# Altium schematic sheet Core_V2.SchDoc
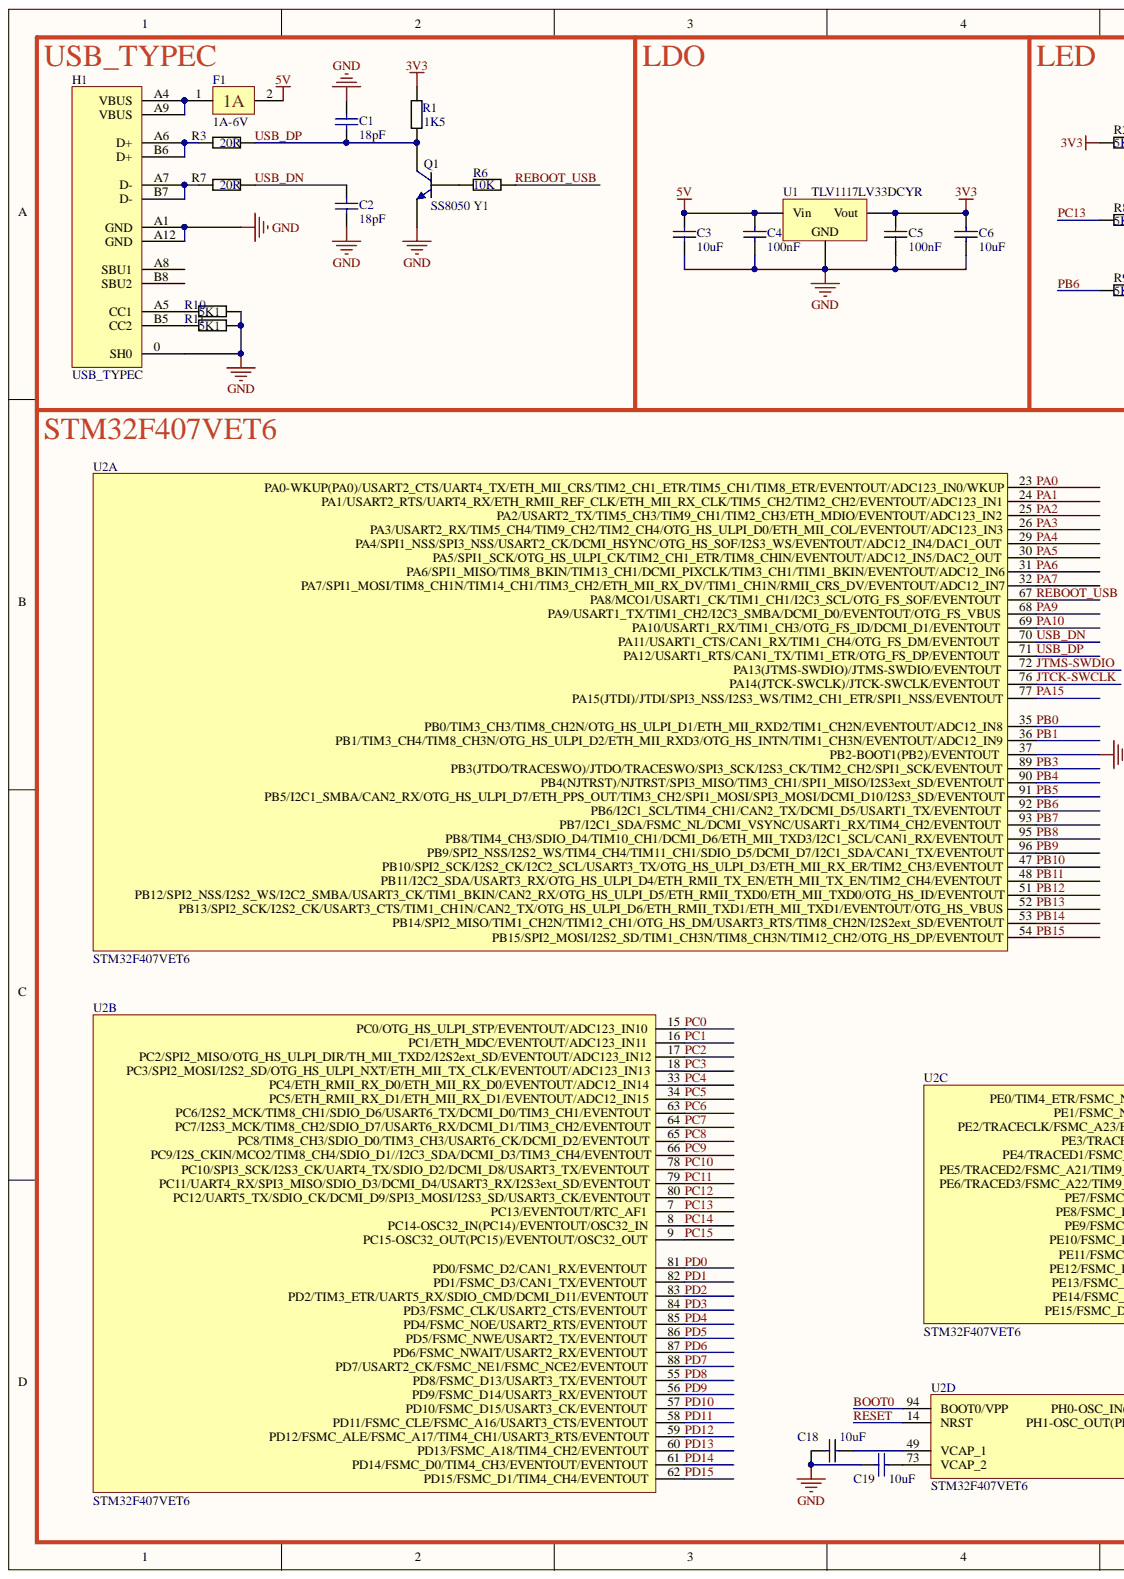
[diagram p0: Core_V2.SchDoc, left]
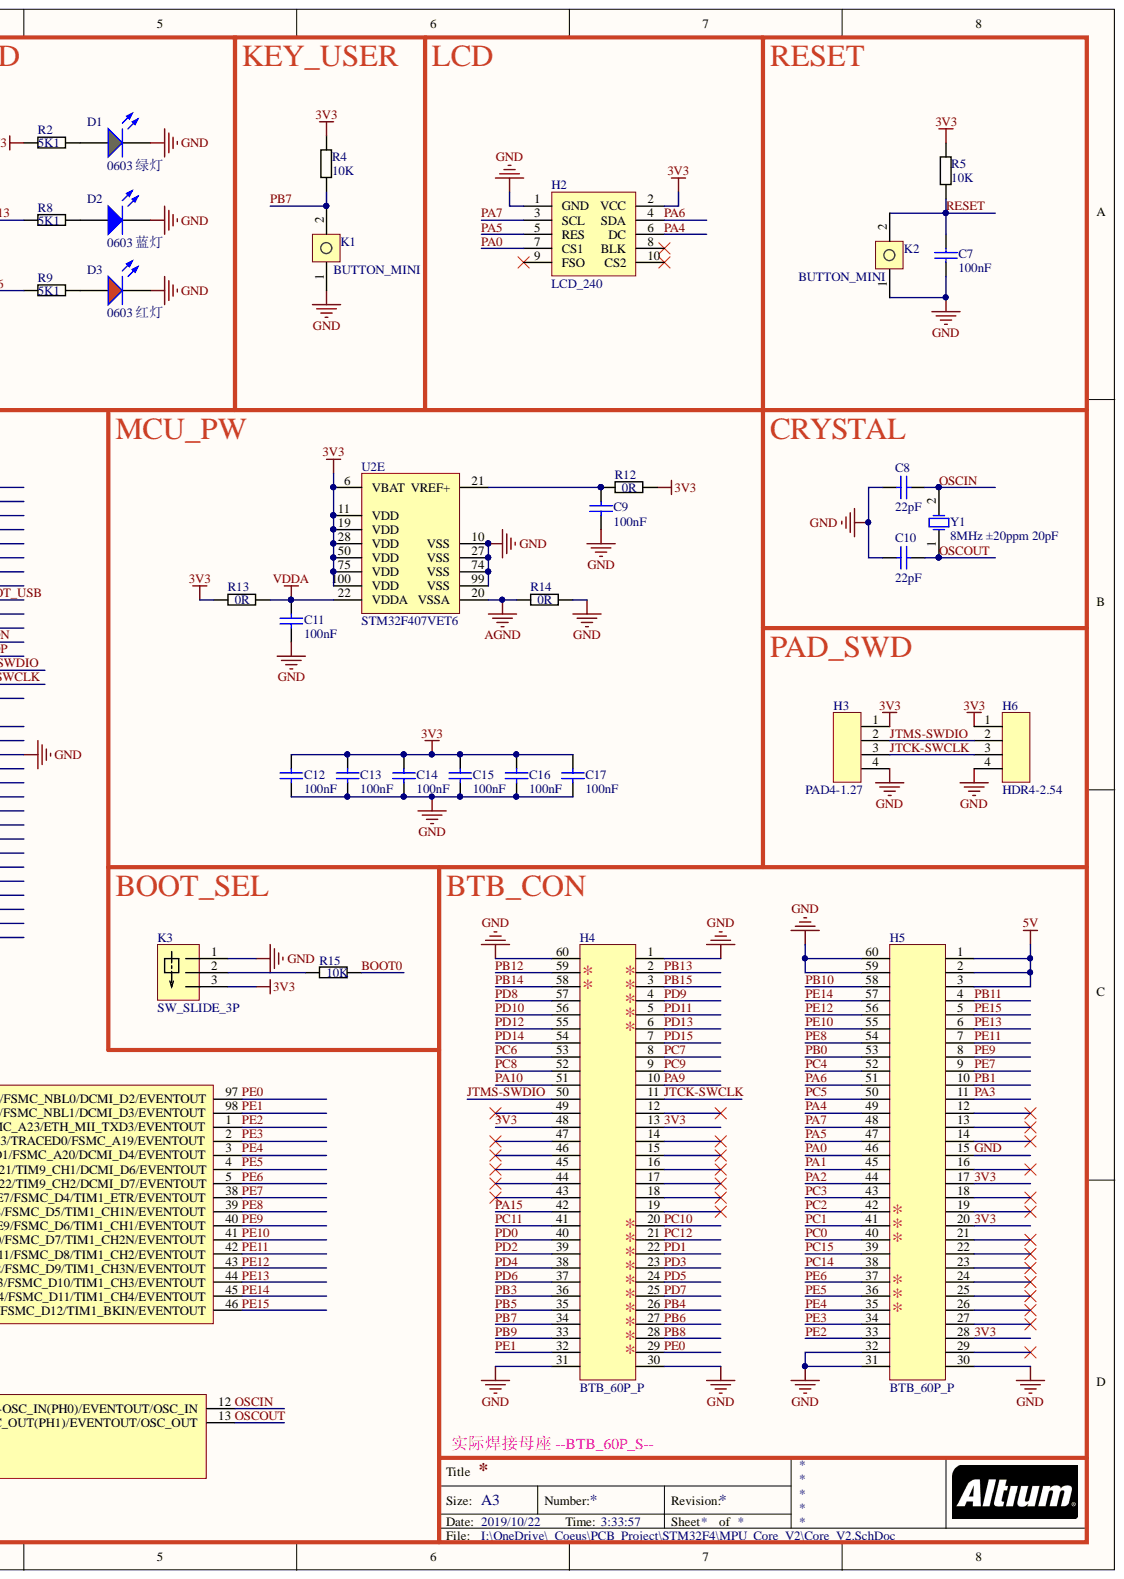
[diagram p1: Core_V2.SchDoc, right]

## Parts
C1  18pF  [0603_C]
C2  18pF  [0603_C]
C3  10uF  [0603_C]
C4  100nF  [0603_C]
C5  100nF  [0603_C]
C6  10uF  [0603_C]
C7  100nF  [0603_C]
C8  22pF  [0603_C]
C9  100nF  [0603_C]
C10  22pF  [0603_C]
C11  100nF  [0603_C]
C12  100nF  [0603_C]
C13  100nF  [0603_C]
C14  100nF  [0603_C]
C15  100nF  [0603_C]
C16  100nF  [0603_C]
C17  100nF  [0603_C]
C18  10uF  [0603_C]
C19  10uF  [0603_C]
D1  0603 绿灯  Package: 0603  [0603_LED_GREEN]
D2  0603 蓝灯  Package: 0603  [0603_LED_BLUE]
D3  0603 红灯  Package: 0603  [0603_LED]
F1  1A-6V  [0805_FUSE]
H1  USB_TYPEC  [USB_TYPEC]
H2  LCD_240  [LCD_240]
H3  PAD4-1.27  [HDR4-1.27]
H4  BTB_60P_P  [BTB_60P_P]
H5  BTB_60P_P  [BTB_60P_P]
H6  HDR4-2.54  [HDR4-2.54]
K1  BUTTON_MINI  [BUTTON_MINI]
K2  BUTTON_MINI  [BUTTON_MINI]
K3  SW_SLIDE_3P  [SW_SLIDE_3P]
Q1  SS8050 Y1  [SOT-23(SOT-23-3)]
R1  1K5  [0603_R]
R2  5K1  [0603_R]
R3  20R  [0603_R]
R4  10K  [0603_R]
R5  10K  [0603_R]
R6  10K  [0603_R]
R7  20R  [0603_R]
R8  5K1  [0603_R]
R9  5K1  [0603_R]
R10  5K1  [0603_R]
R11  5K1  [0603_R]
R12  0R  [0603_R]
R13  0R  [0603_R]
R14  0R  [0603_R]
R15  10K  [0603_R]
U1  TLV1117LV33DCYR  [SOT-223]
U2  STM32F407VET6  [LQFP-100_14x14x05P]  (parts A,B,C,D,E)
Y1  8MHz ±20ppm 20pF  [SMD-5032_2P]

## Nets
5V: C3.2 C4.2 F1.2 H5.1 H5.2 H5.3 U1.3
AGND: R14.1 U2.20(VSSA)
VDDA: C11.2 R13.2 U2.22(VDDA)
3V3: C5.2 C6.2 C12.2 C13.2 C14.2 C15.2 C16.2 C17.2 H2.2(VCC) H3.1 H4.13 H4.48 H5.17 H5.20 H5.28 H6.1 K3.3 R1.2 R2.1 R4.2 R5.2 R12.2 R13.1 U1.2 U2.6(VBAT) U2.11(VDD) U2.19(VDD) U2.28(VDD) U2.50(VDD) U2.75(VDD) U2.100(VDD)
BOOT0: R15.2 U2.94(BOOT0/VPP)
GND: C1.2 C2.1 C3.1 C4.1 C5.1 C6.1 C7.1 C8.1 C9.1 C10.1 C11.1 C12.1 C13.1 C14.1 C15.1 C16.1 C17.1 C18.1 C19.1 D1.K D2.K D3.K H1.0(SH0) H1.A1(GND) H1.A12(GND) H2.1(GND) H3.4 H4.1 H4.30 H4.31 H4.60 H5.15 H5.30 H5.31 H5.32 H5.59 H5.60 H6.4 K1.1 K2.1 K3.1 Q1.2 R10.2 R11.2 R14.2 U1.1 U2.10(VSS) U2.27(VSS) U2.37(PB2-BOOT1(PB2)/EVENTOUT) U2.74(VSS) U2.99(VSS)
JTCK-SWCLK: H3.3 H4.11 H6.3 U2.76(PA14(JTCK-SWCLK)/JTCK-SWCLK/EVENTOUT)
JTMS-SWDIO: H3.2 H4.50 H6.2 U2.72(PA13(JTMS-SWDIO)/JTMS-SWDIO/EVENTOUT)
OSCIN: C8.2 U2.12(PH0-OSC_IN(PH0)/EVENTOUT/OSC_IN) Y1.2
OSCOUT: C10.2 U2.13(PH1-OSC_OUT(PH1)/EVENTOUT/OSC_OUT) Y1.1
PA0: H2.7(CS1) H5.46 U2.23(PA0-WKUP(PA0)/USART2_CTS/UART4_TX/ETH_MII_CRS/TIM2_CH1_ETR/TIM5_CH1/TIM8_ETR/EVENTOUT/ADC123_IN0/WKUP)
PA1: H5.45 U2.24(PA1/USART2_RTS/UART4_RX/ETH_RMII_REF_CLK/ETH_MII_RX_CLK/TIM5_CH2/TIM2_CH2/EVENTOUT/ADC123_IN1)
PA2: H5.44 U2.25(PA2/USART2_TX/TIM5_CH3/TIM9_CH1/TIM2_CH3/ETH_MDIO/EVENTOUT/ADC123_IN2)
PA3: H5.11 U2.26(PA3/USART2_RX/TIM5_CH4/TIM9_CH2/TIM2_CH4/OTG_HS_ULPI_D0/ETH_MII_COL/EVENTOUT/ADC123_IN3)
PA4: H2.6(DC) H5.49 U2.29(PA4/SPI1_NSS/SPI3_NSS/USART2_CK/DCMI_HSYNC/OTG_HS_SOF/I2S3_WS/EVENTOUT/ADC12_IN4/DAC1_OUT)
PA5: H2.5(RES) H5.47 U2.30(PA5/SPI1_SCK/OTG_HS_ULPI_CK/TIM2_CH1_ETR/TIM8_CHIN/EVENTOUT/ADC12_IN5/DAC2_OUT)
PA6: H2.4(SDA) H5.51 U2.31(PA6/SPI1_MISO/TIM8_BKIN/TIM13_CH1/DCMI_PIXCLK/TIM3_CH1/TIM1_BKIN/EVENTOUT/ADC12_IN6)
PA7: H2.3(SCL) H5.48 U2.32(PA7/SPI1_MOSI/TIM8_CH1N/TIM14_CH1/TIM3_CH2/ETH_MII_RX_DV/TIM1_CH1N/RMII_CRS_DV/EVENTOUT/ADC12_IN7)
PA9: H4.10 U2.68(PA9/USART1_TX/TIM1_CH2/I2C3_SMBA/DCMI_D0/EVENTOUT/OTG_FS_VBUS)
PA10: H4.51 U2.69(PA10/USART1_RX/TIM1_CH3/OTG_FS_ID/DCMI_D1/EVENTOUT)
PA15: H4.42 U2.77(PA15(JTDI)/JTDI/SPI3_NSS/I2S3_WS/TIM2_CH1_ETR/SPI1_NSS/EVENTOUT)
PB0: H5.53 U2.35(PB0/TIM3_CH3/TIM8_CH2N/OTG_HS_ULPI_D1/ETH_MII_RXD2/TIM1_CH2N/EVENTOUT/ADC12_IN8)
PB1: H5.10 U2.36(PB1/TIM3_CH4/TIM8_CH3N/OTG_HS_ULPI_D2/ETH_MII_RXD3/OTG_HS_INTN/TIM1_CH3N/EVENTOUT/ADC12_IN9)
PB3: H4.36 U2.89(PB3(JTDO/TRACESWO)/JTDO/TRACESWO/SPI3_SCK/I2S3_CK/TIM2_CH2/SPI1_SCK/EVENTOUT)
PB4: H4.26(*) U2.90(PB4(NJTRST)/NJTRST/SPI3_MISO/TIM3_CH1/SPI1_MISO/I2S3ext_SD/EVENTOUT)
PB5: H4.35 U2.91(PB5/I2C1_SMBA/CAN2_RX/OTG_HS_ULPI_D7/ETH_PPS_OUT/TIM3_CH2/SPI1_MOSI/SPI3_MOSI/DCMI_D10/I2S3_SD/EVENTOUT)
PB6: H4.27(*) R9.1 U2.92(PB6/I2C1_SCL/TIM4_CH1/CAN2_TX/DCMI_D5/USART1_TX/EVENTOUT)
PB7: H4.34 K1.2 R4.1 U2.93(PB7/I2C1_SDA/FSMC_NL/DCMI_VSYNC/USART1_RX/TIM4_CH2/EVENTOUT)
PB8: H4.28(*) U2.95(PB8/TIM4_CH3/SDIO_D4/TIM10_CH1/DCMI_D6/ETH_MII_TXD3/I2C1_SCL/CAN1_RX/EVENTOUT)
PB9: H4.33 U2.96(PB9/SPI2_NSS/I2S2_WS/TIM4_CH4/TIM11_CH1/SDIO_D5/DCMI_D7/I2C1_SDA/CAN1_TX/EVENTOUT)
PB10: H5.58 U2.47(PB10/SPI2_SCK/I2S2_CK/I2C2_SCL/USART3_TX/OTG_HS_ULPI_D3/ETH_MII_RX_ER/TIM2_CH3/EVENTOUT)
PB11: H5.4 U2.48(PB11/I2C2_SDA/USART3_RX/OTG_HS_ULPI_D4/ETH_RMII_TX_EN/ETH_MII_TX_EN/TIM2_CH4/EVENTOUT)
PB12: H4.59(*) U2.51(PB12/SPI2_NSS/I2S2_WS/I2C2_SMBA/USART3_CK/TIM1_BKIN/CAN2_RX/OTG_HS_ULPI_D5/ETH_RMII_TXD0/ETH_MII_TXD0/OTG_HS_ID/EVENTOUT)
PB13: H4.2(*) U2.52(PB13/SPI2_SCK/I2S2_CK/USART3_CTS/TIM1_CH1N/CAN2_TX/OTG_HS_ULPI_D6/ETH_RMII_TXD1/ETH_MII_TXD1/EVENTOUT/OTG_HS_VBUS)
PB14: H4.58(*) U2.53(PB14/SPI2_MISO/TIM1_CH2N/TIM12_CH1/OTG_HS_DM/USART3_RTS/TIM8_CH2N/I2S2ext_SD/EVENTOUT)
PB15: H4.3(*) U2.54(PB15/SPI2_MOSI/I2S2_SD/TIM1_CH3N/TIM8_CH3N/TIM12_CH2/OTG_HS_DP/EVENTOUT)
PC0: H5.40(*) U2.15(PC0/OTG_HS_ULPI_STP/EVENTOUT/ADC123_IN10)
PC1: H5.41(*) U2.16(PC1/ETH_MDC/EVENTOUT/ADC123_IN11)
PC2: H5.42(*) U2.17(PC2/SPI2_MISO/OTG_HS_ULPI_DIR/TH_MII_TXD2/I2S2ext_SD/EVENTOUT/ADC123_IN12)
PC3: H5.43 U2.18(PC3/SPI2_MOSI/I2S2_SD/OTG_HS_ULPI_NXT/ETH_MII_TX_CLK/EVENTOUT/ADC123_IN13)
PC4: H5.52 U2.33(PC4/ETH_RMII_RX_D0/ETH_MII_RX_D0/EVENTOUT/ADC12_IN14)
PC5: H5.50 U2.34(PC5/ETH_RMII_RX_D1/ETH_MII_RX_D1/EVENTOUT/ADC12_IN15)
PC6: H4.53 U2.63(PC6/I2S2_MCK/TIM8_CH1/SDIO_D6/USART6_TX/DCMI_D0/TIM3_CH1/EVENTOUT)
PC7: H4.8 U2.64(PC7/I2S3_MCK/TIM8_CH2/SDIO_D7/USART6_RX/DCMI_D1/TIM3_CH2/EVENTOUT)
PC8: H4.52 U2.65(PC8/TIM8_CH3/SDIO_D0/TIM3_CH3/USART6_CK/DCMI_D2/EVENTOUT)
PC9: H4.9 U2.66(PC9/I2S_CKIN/MCO2/TIM8_CH4/SDIO_D1//I2C3_SDA/DCMI_D3/TIM3_CH4/EVENTOUT)
PC10: H4.20(*) U2.78(PC10/SPI3_SCK/I2S3_CK/UART4_TX/SDIO_D2/DCMI_D8/USART3_TX/EVENTOUT)
PC11: H4.41 U2.79(PC11/UART4_RX/SPI3_MISO/SDIO_D3/DCMI_D4/USART3_RX/I2S3ext_SD/EVENTOUT)
PC12: H4.21(*) U2.80(PC12/UART5_TX/SDIO_CK/DCMI_D9/SPI3_MOSI/I2S3_SD/USART3_CK/EVENTOUT)
PC13: R8.1 U2.7(PC13/EVENTOUT/RTC_AF1)
PC14: H5.38 U2.8(PC14-OSC32_IN(PC14)/EVENTOUT/OSC32_IN)
PC15: H5.39 U2.9(PC15-OSC32_OUT(PC15)/EVENTOUT/OSC32_OUT)
PD0: H4.40 U2.81(PD0/FSMC_D2/CAN1_RX/EVENTOUT)
PD1: H4.22(*) U2.82(PD1/FSMC_D3/CAN1_TX/EVENTOUT)
PD2: H4.39 U2.83(PD2/TIM3_ETR/UART5_RX/SDIO_CMD/DCMI_D11/EVENTOUT)
PD3: H4.23(*) U2.84(PD3/FSMC_CLK/USART2_CTS/EVENTOUT)
PD4: H4.38 U2.85(PD4/FSMC_NOE/USART2_RTS/EVENTOUT)
PD5: H4.24(*) U2.86(PD5/FSMC_NWE/USART2_TX/EVENTOUT)
PD6: H4.37 U2.87(PD6/FSMC_NWAIT/USART2_RX/EVENTOUT)
PD7: H4.25(*) U2.88(PD7/USART2_CK/FSMC_NE1/FSMC_NCE2/EVENTOUT)
PD8: H4.57 U2.55(PD8/FSMC_D13/USART3_TX/EVENTOUT)
PD9: H4.4(*) U2.56(PD9/FSMC_D14/USART3_RX/EVENTOUT)
PD10: H4.56 U2.57(PD10/FSMC_D15/USART3_CK/EVENTOUT)
PD11: H4.5(*) U2.58(PD11/FSMC_CLE/FSMC_A16/USART3_CTS/EVENTOUT)
PD12: H4.55 U2.59(PD12/FSMC_ALE/FSMC_A17/TIM4_CH1/USART3_RTS/EVENTOUT)
PD13: H4.6(*) U2.60(PD13/FSMC_A18/TIM4_CH2/EVENTOUT)
PD14: H4.54 U2.61(PD14/FSMC_D0/TIM4_CH3/EVENTOUT/EVENTOUT)
PD15: H4.7 U2.62(PD15/FSMC_D1/TIM4_CH4/EVENTOUT)
PE0: H4.29(*) U2.97(PE0/TIM4_ETR/FSMC_NBL0/DCMI_D2/EVENTOUT)
PE1: H4.32 U2.98(PE1/FSMC_NBL1/DCMI_D3/EVENTOUT)
PE2: H5.33 U2.1(PE2/TRACECLK/FSMC_A23/ETH_MII_TXD3/EVENTOUT)
PE3: H5.34 U2.2(PE3/TRACED0/FSMC_A19/EVENTOUT)
PE4: H5.35(*) U2.3(PE4/TRACED1/FSMC_A20/DCMI_D4/EVENTOUT)
PE5: H5.36(*) U2.4(PE5/TRACED2/FSMC_A21/TIM9_CH1/DCMI_D6/EVENTOUT)
PE6: H5.37(*) U2.5(PE6/TRACED3/FSMC_A22/TIM9_CH2/DCMI_D7/EVENTOUT)
PE7: H5.9 U2.38(PE7/FSMC_D4/TIM1_ETR/EVENTOUT)
PE8: H5.54 U2.39(PE8/FSMC_D5/TIM1_CH1N/EVENTOUT)
PE9: H5.8 U2.40(PE9/FSMC_D6/TIM1_CH1/EVENTOUT)
PE10: H5.55 U2.41(PE10/FSMC_D7/TIM1_CH2N/EVENTOUT)
PE11: H5.7 U2.42(PE11/FSMC_D8/TIM1_CH2/EVENTOUT)
PE12: H5.56 U2.43(PE12/FSMC_D9/TIM1_CH3N/EVENTOUT)
PE13: H5.6 U2.44(PE13/FSMC_D10/TIM1_CH3/EVENTOUT)
PE14: H5.57 U2.45(PE14/FSMC_D11/TIM1_CH4/EVENTOUT)
PE15: H5.5 U2.46(PE15/FSMC_D12/TIM1_BKIN/EVENTOUT)
REBOOT_USB: R6.1 U2.67(PA8/MCO1/USART1_CK/TIM1_CH1/I2C3_SCL/OTG_FS_SOF/EVENTOUT)
RESET: C7.2 K2.2 R5.1 U2.14(NRST)
USB_DN: C2.2 R7.2 U2.70(PA11/USART1_CTS/CAN1_RX/TIM1_CH4/OTG_FS_DM/EVENTOUT)
USB_DP: C1.1 Q1.3 R1.1 R3.2 U2.71(PA12/USART1_RTS/CAN1_TX/TIM1_ETR/OTG_FS_DP/EVENTOUT)
NetC9_2: C9.2 R12.1 U2.21(VREF+)
NetC18_2: C18.2 U2.49(VCAP_1)
NetC19_2: C19.2 U2.73(VCAP_2)
NetD1_A: D1.A R2.2
NetD2_A: D2.A R8.2
NetD3_A: D3.A R9.2
NetF1_1: F1.1 H1.A4(VBUS) H1.A9(VBUS)
NetH1_A5: H1.A5(CC1) R10.1
NetH1_A6: H1.A6(D+) H1.B6(D+) R3.1
NetH1_A7: H1.A7(D-) H1.B7(D-) R7.1
NetH1_B5: H1.B5(CC2) R11.1
NetK3_2: K3.2 R15.1
NetQ1_1: Q1.1 R6.2

Not connected: H1 A8(SBU1) B8(SBU2); H2 8(BLK) 9(FSO) 10(CS2); H4 12 14 15 16 17 18 19 43 44 45 46 47 49; H5 12 13 14 16 18 19 21 22 23 24 25 26 27 29

## Library data of the parts
C1 C2 C7 = CAP-0603: 贴片电容; Component Kind: Standard; ComponentLink1Description: 供应商链接; ComponentLink1URL: http://www.szlcsc.com/product/details_1921.html; Library Name: MPU_F4.IntLib; Package: 0402; Supplier: LC; SuppliersPartNumber: C1569
C3 C6 = CAP-0603: 0603贴片电容 10UF(106) ±20% 25V; Component Kind: Standard; ComponentLink1Description: 供应商链接; ComponentLink1URL: http://www.szlcsc.com/product/details_1921.html; Library Name: MPU_F4.IntLib; Package: 0402; Supplier: LC; SuppliersPartNumber: C1569
C4 C5 C9 C11-C17 = CAP-0603: 0603贴片电容 100NF (104) ±10% 50V X7R; Component Kind: Standard; ComponentLink1Description: 供应商链接; ComponentLink1URL: http://www.szlcsc.com/product/details_1921.html; Library Name: MPU_F4.IntLib; Package: 0402; Supplier: LC; SuppliersPartNumber: C1569
C8 C10 = CAP-0603: 0603 贴片电容 22PF (220) ±5% 50V C0G; Component Kind: Standard; ComponentLink1Description: 供应商链接; ComponentLink1URL: http://www.szlcsc.com/product/details_1921.html; Library Name: MPU_F4.IntLib; Package: 0402; Supplier: LC; SuppliersPartNumber: C1569
C18 C19 = CAP-0603: 10uF (106) 10% 10V; Component Kind: Standard; ComponentLink1Description: 供应商链接; ComponentLink1URL: http://www.szlcsc.com/product/details_1921.html; Library Name: MPU_F4.IntLib; Package: 0402; Supplier: LC; SuppliersPartNumber: C1569
D1 = 0603 绿灯: 发光二极管; Component Kind: Standard; ComponentLink1Description: 供应商链接; ComponentLink1URL: http://www.szlcsc.com/product/details_73152.html; Library Name: MPU_F4.IntLib; Supplier: LC; SuppliersPartNumber: C72043
D2 = 0603 蓝灯: 发光二极管; Component Kind: Standard; ComponentLink1Description: 供应商链接; ComponentLink1URL: http://www.szlcsc.com/product/details_73150.html; Library Name: MPU_F4.IntLib; Supplier: LC; SuppliersPartNumber: C72041
D3 = 0603 红灯: 发光二极管; Component Kind: Standard; ComponentLink1Description: 供应商链接; ComponentLink1URL: http://www.szlcsc.com/product/details_2659.html; Library Name: MPU_F4.IntLib; Supplier: LC; SuppliersPartNumber: C2286
F1 = FUSE-0805: Component Kind: Standard; Library Name: MPU_F4.IntLib
K1 K2 = BUTTON_MINI: 龟仔按键 微动开关; Component Kind: Standard; Library Name: MPU_F4.IntLib
K3 = SW_SLIDE_3P: 贴片 滑动开关 6脚; Component Kind: Standard; Library Name: MPU_F4.IntLib
Q1 = SOT-23 SS8050 Y1: 三极管; Component Kind: Standard; ComponentLink1Description: 供应商链接; ComponentLink1URL: http://www.szlcsc.com/product/details_2507.html; Library Name: MPU_F4.IntLib; Package: SOT-23; Supplier: LC; SuppliersPartNumber: C2150
R1-R15 = RES-0603: Component Kind: Standard; Library Name: MPU_F4.IntLib
U1 = TLV1117LV33DCYR: 低压差线性稳压(LDO); Component Kind: Standard; ComponentLink1Description: 供应商链接; ComponentLink1URL: http://www.szlcsc.com/product/details_6652.html; Library Name: MPU_F4.IntLib; Package: SOT-223; Supplier: LC; SuppliersPartNumber: C6186
U2 = STM32F407VET6: ARM; Component Kind: Standard; ComponentLink1Description: 供应商链接; ComponentLink1URL: http://www.szlcsc.com/product/details_29482.html; Library Name: MPU_F4.SchLib; Package: LQFP-100_14x14x05P; Supplier: LC; SuppliersPartNumber: C28730
Y1 = SMD-5032_2P 8MHz 20ppm 20pF: 贴片晶振; Component Kind: Standard; ComponentLink1Description: 供应商链接; ComponentLink1URL: http://www.szlcsc.com/product/details_117214.html; Library Name: MPU_F4.IntLib; Package: SMD-5032_2P; Supplier: LC; SuppliersPartNumber: C115962
H1 = USB_TYPEC: Component Kind: Standard; Library Name: MPU_F4.IntLib
H2 = LCD_240: Component Kind: Standard; Library Name: MPU_F4.SchLib
H3 = PAD4-1.27: Component Kind: Standard; Library Name: MPU_F4.IntLib
H4 H5 = BTB_60P_P: Component Kind: Standard; Library Name: MPU_F4.IntLib
H6 = HDR4-2.54: Component Kind: Standard; Library Name: MPU_F4.SchLib
